# Altium schematic sheet Sch_TSAL.SchDoc(Sch_TSAL)
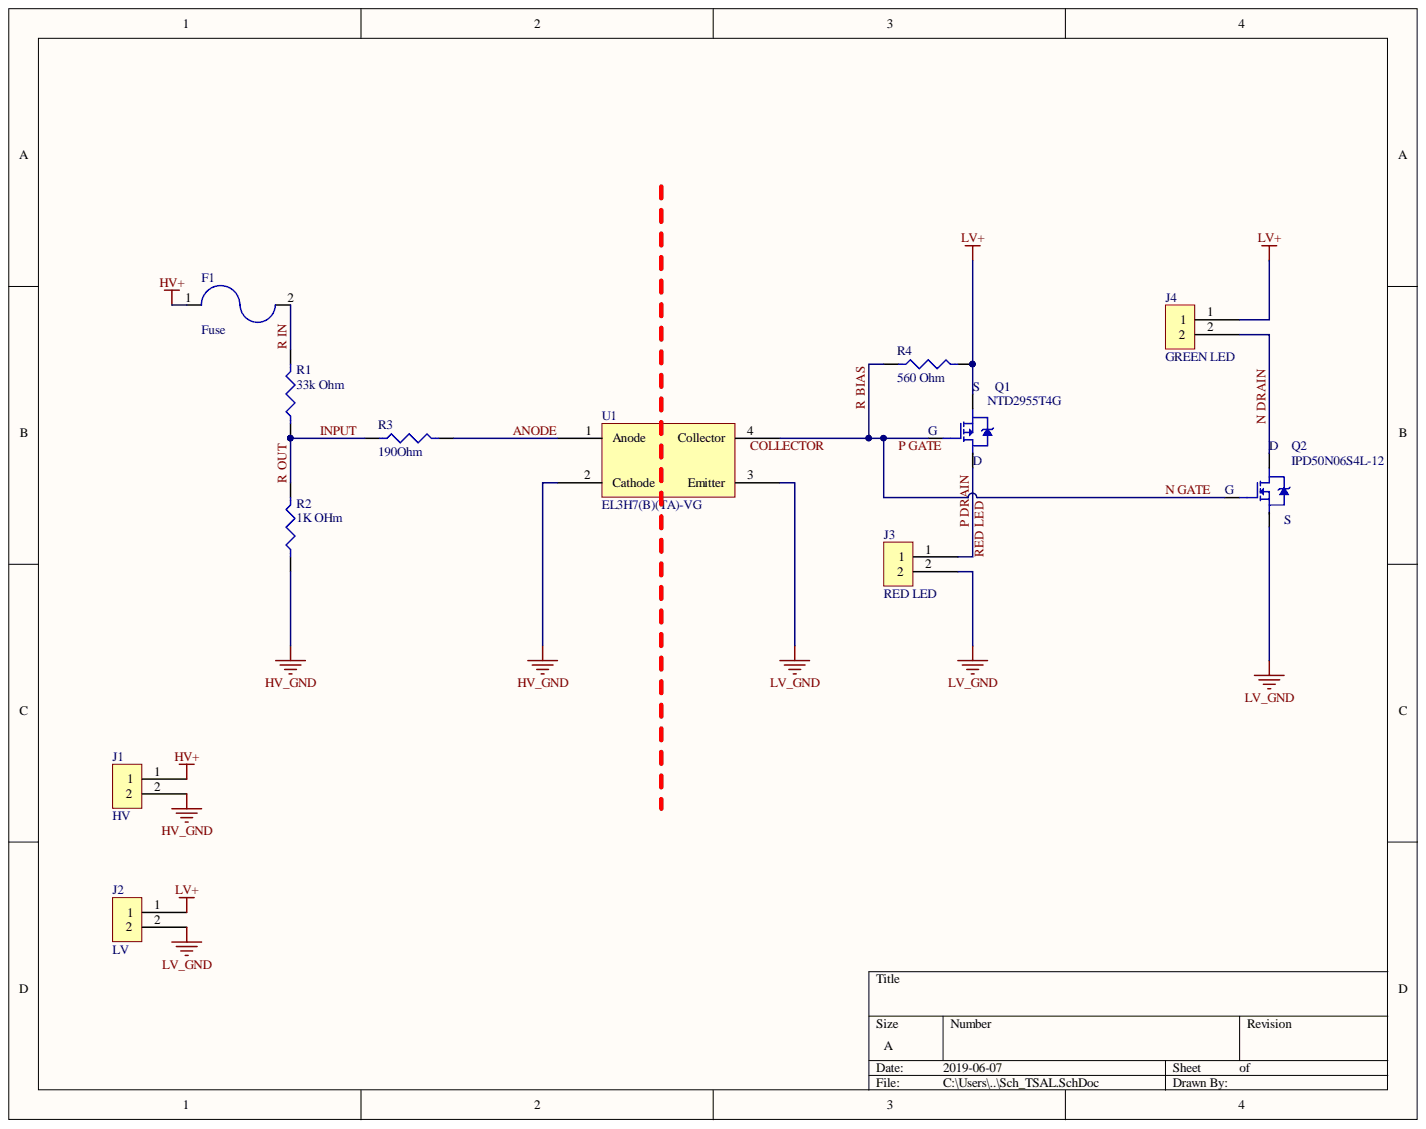
[diagram p0: Sch_TSAL.SchDoc]

## Parts
F1  Fuse  [FUSE_SMD]
J1  HV  [2_PIN_CONNECTOR]
J2  LV  [2_PIN_CONNECTOR]
J3  RED LED  [2_PIN_CONNECTOR]
J4  GREEN LED  [2_PIN_CONNECTOR]
Q1  NTD2955T4G  [DPAK228P991X238-3N]
Q2  IPD50N06S4L-12  [DPAK228P998X235-3N]
R1  33k Ohm  [RESC6332X07N]
R2  1K OHm  [RESC2012X06N]
R3  190Ohm  [RESC2012X06N]
R4  560 Ohm  [RESC2012X06N]
U1  EL3H7(B)(TA)-VG  [SOIC127P700X200-4N]

## Nets
HV+: F1.1 J1.1
HV_GND: J1.2 R2.1 U1.2(Cathode)
LV+: J2.1 J4.1 Q1.4 R4.2
LV_GND: J2.2 J3.2 Q2.3 U1.3(Emitter)
ANODE: R3.2 U1.1(Anode)
INPUT: R1.1 R2.2 R3.1
N DRAIN: J4.2 Q2.4
N GATE: Q1.1 Q2.1 R4.1 U1.4(Collector)
P DRAIN: J3.1 Q1.3
R IN: F1.2 R1.2
COLLECTOR:
P GATE:
RED LED:

## Library data of the parts
F1 = 0678D0800-02: Manufacturer: Bel; Voltage Rating (DC): 250V; Voltage Rating (AC): 350V; Fuse Type: Board Mount (Cartridge Style Excluded); DC Cold Resistance: 380mR; Current Rating: 800mA; Approvals: cURus; Supplier Part Number 1: 507-2258-6-ND; Supplier 1: Digi-Key; Stock 1: 2000; Pricing 1: 1=1.2, 5=1.164, 10=1.089, 50=0.901, 100=0.7508, 250=0.732, 500=0.61936, 1000=0.54429 (USD); Packaging: Tape and Reel; Mount: Surface Mount; Min Operating Temperature: -55°C; Max Operating Temperature: 125°C; Manufacturer Part Number: 0678D0800-02; Component Kind: Standard; Case/Package: SMD/SMT; Library Name: Schlib1_TSAL.SchLib
J1-J4 = 0705530001: Manufacturer: Molex; Pitch: 2.54mm; Number of Positions: 2; Contact Resistance: 30mR; Connector Type: Male Pin; Termination: Solder; Supplier Part Number 1: WM4900-ND; Supplier 1: Digi-Key; Stock 1: 14591; Radiation Hardening: No; Pricing 1: 1=0.71, 72=0.53486, 144=0.51264, 504=0.44575, 1008=0.36775, 5040=0.32318, 10008=0.30089 (USD); Packaging: Rail/Tube; Orientation: Right Angle; Number of Rows: 1; Number of Contacts: 2; Mount: Through Hole; Min Operating Temperature: -40°C; Max Operating Temperature: 105°C; Manufacturer Part Number: 0705530001; Length: 7.37mm; Housing Material: Polyester; Housing Color: Black; Fastening Type: Latch; Depth: 13.59mm; Contact Plating: Gold; Contact Mating Length: 0.24inch; Contact Material: Bronze; ComponentLink2URL: http://www.molex.com/webdocs/datasheets/pdf/en-us/0705530001_PCB_HEADERS.pdf?src-supplier=Avnet; ComponentLink2Description: http://www.molex.com/webdocs/datasheets/pdf/en-us/0705530001_PCB_HEADERS.pdf?src-supplier=Avnet; ComponentLink1URL: https://www.molex.com/pdm_docs/ps/PS-70400.pdf?src-supplier=Digi-Key; ComponentLink1Description: https://www.molex.com/pdm_docs/ps/PS-70400.pdf?src-supplier=Digi-Key; Component Kind: Standard; Color: Black; Library Name: Schlib1_TSAL.SchLib
Q1 = NTD2955T4G: Trans MOSFET P-CH 60V 12A 3-Pin(2+Tab) DPAK T/R; Manufacturer: ON Semiconductor; Voltage Rating (DC): -60V; Turn-On Delay Time: 10ns; Turn-Off Delay Time: 26ns; Threshold Voltage: -2.8V; Rise Time: 45ns; Rds On Max: 180mR; Power Dissipation: 55W; Number of Elements: 1; Input Capacitance: 750pF; Gate to Source Voltage (Vgs): 20V; Fall Time: 48ns; Element Configuration: Single; Drain to Source Resistance: 155mR; Current Rating: -12A; Width: 6.22mm; Supplier Part Number 1: NTD2955T4GOSCT-ND; Supplier 1: Digi-Key; Stock 1: 44767; REACH SVHC: No SVHC; Radiation Hardening: No; Pricing 1: 1=1.04, 10=0.912, 100=0.7036, 500=0.52118, 1000=0.41694 (USD); Packaging: Tape and Reel; Package Quantity: 1; On-State Resistance: 180mR; Number of Pins: 3; Number of Channels: 1; Nominal Vgs: -2.8V; Min Operating Temperature: -55°C; Max Power Dissipation: 55W; Max Operating Temperature: 175°C; Manufacturer Part Number: NTD2955T4G; Length: 6.73mm; Lead Free: Lead Free; Height: 2.38mm; Drain to Source Voltage (Vdss): -60V; Drain to Source Breakdown Voltage: -60V; Continuous Drain Current (ID): 12A; Contact Plating: Matte,Tin; ComponentLink5URL: http://www.jameco.com/Jameco/Products/ProdDS/1178118.pdf?src-supplier=Jameco; ComponentLink5Description: http://www.jameco.com/Jameco/Products/ProdDS/1178118.pdf?src-supplier=Jameco; ComponentLink4URL: http://www.onsemi.com/pub/Collateral/NTD2955-D.PDF?src-supplier=Digi-Key; ComponentLink4Description: http://www.onsemi.com/pub/Collateral/NTD2955-D.PDF?src-supplier=Digi-Key; ComponentLink3URL: http://www.onsemi.com/pub_link/Collateral/NTD2955-D.PDF?src-supplier=Element14; ComponentLink3Description: http://www.onsemi.com/pub_link/Collateral/NTD2955-D.PDF?src-supplier=Element14; ComponentLink2URL: http://www.onsemi.com/pub/Collateral/NTD2955-D.PDF?src-supplier=Avnet+Europe; ComponentLink2Description: http://www.onsemi.com/pub/Collateral/NTD2955-D.PDF?src-supplier=Avnet+Europe; ComponentLink1URL: http://www.onsemi.com/pub/Collateral/NTD2955-D.PDF?src-supplier=Avnet; ComponentLink1Description: http://www.onsemi.com/pub/Collateral/NTD2955-D.PDF?src-supplier=Avnet; Component Kind: Standard; Case/Package: DPAK; Library Name: Schlib1_TSAL.SchLib
Q2 = IPD50N06S4L-12: MOSFET N-CH 60V 50A TO252-3-11; Manufacturer: Infineon; Turn-On Delay Time: 6ns; Turn-Off Delay Time: 25ns; Rise Time: 2ns; Rds On Max: 12mR; Max Power Dissipation: 50W; Input Capacitance: 2.89nF; Gate to Source Voltage (Vgs): 16V; Fall Time: 5ns; Element Configuration: Single; Drain to Source Resistance: 21.6mR; Width: 6.22mm; Supplier Part Number 1: IPD50N06S4L12ATMA1CT-ND; Supplier 1: Digi-Key; Stock 1: 0; Pricing 1: 1=0.78, 10=0.689, 100=0.5268, 500=0.41736, 1000=0.33429 (USD); Packaging: Tape and Reel; Package Quantity: 2500; Number of Pins: 3; Min Operating Temperature: -55°C; Max Operating Temperature: 175°C; Max Dual Supply Voltage: 60V; Manufacturer Part Number: IPD50N06S4L-12; Length: 6.5mm; Lead Free: Lead Free; Height: 2.3mm; Halogen Free: Halogen Free; Drain to Source Voltage (Vdss): 60V; Continuous Drain Current (ID): 50A; ComponentLink1URL: http://www.infineon.com/dgdl/Infineon-IPD50N06S4L_12-DS-v01_00-en.pdf?folderId=db3a30431a5c32f2011a8084a04a6500&fileId=db3a30431ff9881501203885cc580ca0&ack=t&src-supplier=Digi-Key; ComponentLink1Description: http://www.infineon.com/dgdl/Infineon-IPD50N06S4L_12-DS-v01_00-en.pdf?folderId=db3a30431a5c32f2011a8084a04a6500&fileId=db3a30431ff9881501203885cc580ca0&ack=t&src-supplier=Digi-Key; Component Kind: Standard; Case/Package: TO-252-3; Library Name: Schlib1_TSAL.SchLib
R1 = RMCF2512JT33K0: RES SMD 33K OHM 5% 1W 2512; Manufacturer: Stackpole Electronics; Temperature Coefficient: 100ppm/°C; Resistance: 33kR; Width: 0.126inch; Tolerance: 5%; Supplier Part Number 1: RMCF2512JT33K0CT-ND; Supplier 1: Digi-Key; Stock 1: 37651; Radiation Hardening: No; Pricing 1: 1=0.3, 10=0.254, 100=0.0993, 1000=0.04158 (USD); Power Rating: 1W; Packaging: Tape and Reel; Number of Terminations: 2; Mount: Surface Mount; Min Operating Temperature: -55°C; Max Power Dissipation: 1W; Max Operating Temperature: 155°C; Manufacturer Part Number: RMCF2512JT33K0; Length: 0.248inch; Lead Free: Lead Free; Height: 0.028inch; Features: Automotive AEC-Q200; Contact Plating: Tin,Matte; Composition: Thick Film; Component Kind: Standard; Case Code (Metric): 6432; Case Code (Imperial): 2512; Case/Package: 2512; Library Name: Schlib1_TSAL.SchLib
R2 = RNCP0805FTD1K00: RES 1K OHM 1% 1/4W 0805; Manufacturer: Stackpole Electronics; Temperature Coefficient: 100ppm/°C; Resistance: 1kR; Power Rating: 250mW; Width: 0.049inch; Tolerance: 1%; Supplier Part Number 1: RNCP0805FTD1K00DKR-ND; Supplier 1: Digi-Key; Stock 1: 903429; Pricing 1: 1=0.1, 10=0.072, 100=0.0277, 1000=0.0122, 2500=0.01053 (USD); Packaging: Tape and Reel; Number of Terminations: 2; Mount: Surface Mount; Min Operating Temperature: -55°C; Max Power Dissipation: 250mW; Max Operating Temperature: 155°C; Manufacturer Part Number: RNCP0805FTD1K00; Length: 0.079inch; Lead Free: Lead Free; Height: 0.024inch; Features: Moisture Resistant,Anti-Sulfur; Composition: Thin Film; Component Kind: Standard; Case Code (Metric): 2012; Case Code (Imperial): 0805; Case/Package: 0805; Library Name: Schlib1_TSAL.SchLib
R3 = RC0805FR-0719R1L: Manufacturer: Yageo; Voltage Rating (DC): 150V; Tolerance: 1%; Temperature Coefficient: 100ppm/°C; Resistance: 19.1R; Power Rating: 125mW; Width: 1.25mm; Voltage Rating: 150V; Termination: SMD/SMT; Supplier Part Number 1: 311-19.1CRCT-ND; Supplier 1: Digi-Key; Stock 1: 17015; Radiation Hardening: No; Pricing 1: 1=0.1, 10=0.042, 100=0.017, 1000=0.00762, 2500=0.00662 (USD); Packaging: Tape and Reel; Min Operating Temperature: -55°C; Military Standard: Not; Max Operating Temperature: 155°C; Manufacturer Part Number: RC0805FR-0719R1L; Length: 2mm; Lead Free: Lead Free; Height: 0.5mm; Depth: 1.25mm; Contact Plating: Tin,Matte; Composition: Thick Film; Component Kind: Standard; Case Code (Metric): 2012; Case Code (Imperial): 0805; Case/Package: 0805; Library Name: Schlib1_TSAL.SchLib
R4 = ESR10EZPJ561: RES SMD 560 OHM 5% 0.4W 0805; Manufacturer: Rohm; Temperature Coefficient: 200ppm/°C; Resistance: 560R; Power Rating: 250mW; Max Power Dissipation: 400mW; Width: 0.049inch; Tolerance: 5%; Supplier Part Number 1: RHM560KDKR-ND; Supplier 1: Digi-Key; Stock 1: 10361; Pricing 1: 1=0.1, 10=0.083, 100=0.0318, 1000=0.01398, 2500=0.01207 (USD); Packaging: Tape and Reel; Package Quantity: 1; Number of Terminations: 2; Min Operating Temperature: -55°C; Max Operating Temperature: 155°C; Manufacturer Part Number: ESR10EZPJ561; Length: 0.079inch; Lead Free: Lead Free; Height: 0.026inch; Features: Automotive AEC-Q200; Composition: Thick Film; ComponentLink3URL: http://www1.futureelectronics.com/doc/ROHM%20SEMICONDUCTOR/ESR10EZPD.pdf?src-supplier=Future+Electronics; ComponentLink3Description: http://www1.futureelectronics.com/doc/ROHM%20SEMICONDUCTOR/ESR10EZPD.pdf?src-supplier=Future+Electronics; ComponentLink2URL: https://www.rohm.com/datasheet/ESR01MZPF/esr-e?src-supplier=Digi-Key; ComponentLink2Description: https://www.rohm.com/datasheet/ESR01MZPF/esr-e?src-supplier=Digi-Key; ComponentLink1URL: http://www.rohm.com/web/global/datasheet/ESR01MZPF/esr-e?src-supplier=Digi-Key; ComponentLink1Description: http://www.rohm.com/web/global/datasheet/ESR01MZPF/esr-e?src-supplier=Digi-Key; Component Kind: Standard; Case Code (Metric): 2012; Case Code (Imperial): 0805; Case/Package: 0805; Library Name: Schlib1_TSAL.SchLib
U1 = EL3H7(B)(TA)-VG: OPTOISOLATOR 3.75KV TRANS 4-SSOP; Manufacturer: Everlight; Rise Time: 18000ns; Reverse Breakdown Voltage: 6V; Power Dissipation: 200mW; Output Current per Channel: 50mA; Number of Elements: 1; Max Output Voltage: 80V; Forward Voltage: 1.4V; Current Transfer Ratio: 260%; Collector Emitter Saturation Voltage: 200mV; Approvals: UL; Supplier Part Number 1: 1080-1197-6-ND; Supplier 1: Digi-Key; Stock 1: 119652; Radiation Hardening: No; Pricing 1: 1=0.42, 10=0.304, 25=0.248, 100=0.1985, 250=0.16752, 500=0.1427, 1000=0.11167, 2500=0.10237 (USD); Packaging: Tape and Reel; Package Quantity: 1; Number of Pins: 4; Number of Channels: 1; Mount: Surface Mount; Min Operating Temperature: -55°C; Max Power Dissipation: 200mW; Max Operating Temperature: 125°C; Max Input Current: 50mA; Max Collector Current: 50mA; Manufacturer Part Number: EL3H7(B)(TA)-VG; Lead Free: Lead Free; Isolation Voltage: 3.75kV; Forward Current: 50mA; Fall Time: 18000ns; ComponentLink3URL: https://www.verical.com/server-webapp/html/getDatasheet?partID=416716&src-supplier=Verical; ComponentLink3Description: https://www.verical.com/server-webapp/html/getDatasheet?partID=416716&src-supplier=Verical; ComponentLink2URL: https://everlightamericas.com/index.php?controller=attachment&amp;id_attachment=2584&src-supplier=Digi-Key; ComponentLink2Description: https://everlightamericas.com/index.php?controller=attachment&amp;id_attachment=2584&src-supplier=Digi-Key; ComponentLink1URL: https://everlightamericas.com/index.php?controller=attachment&id_attachment=2584&src-supplier=Digi-Key; ComponentLink1Description: https://everlightamericas.com/index.php?controller=attachment&id_attachment=2584&src-supplier=Digi-Key; Component Kind: Standard; Collector Emitter Voltage (VCEO): 80V; Collector Emitter Breakdown Voltage: 80V; Case/Package: SSOP; Library Name: Schlib1_TSAL.SchLib
